# Altium schematic sheet main.SchDoc
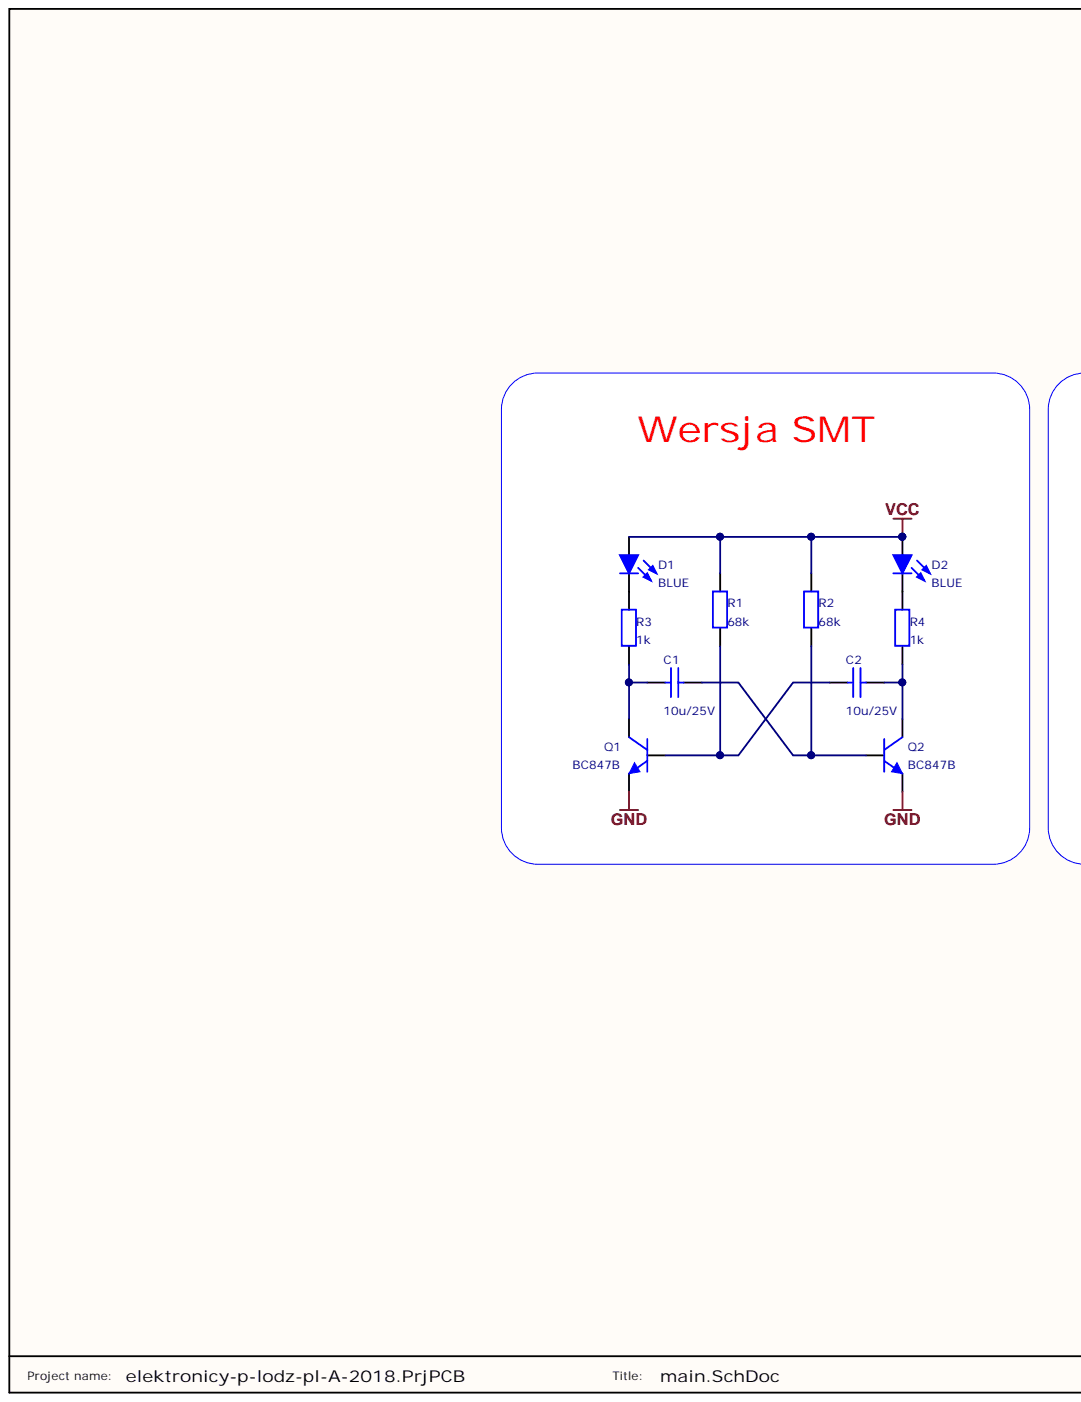
[diagram p0: main.SchDoc, left]
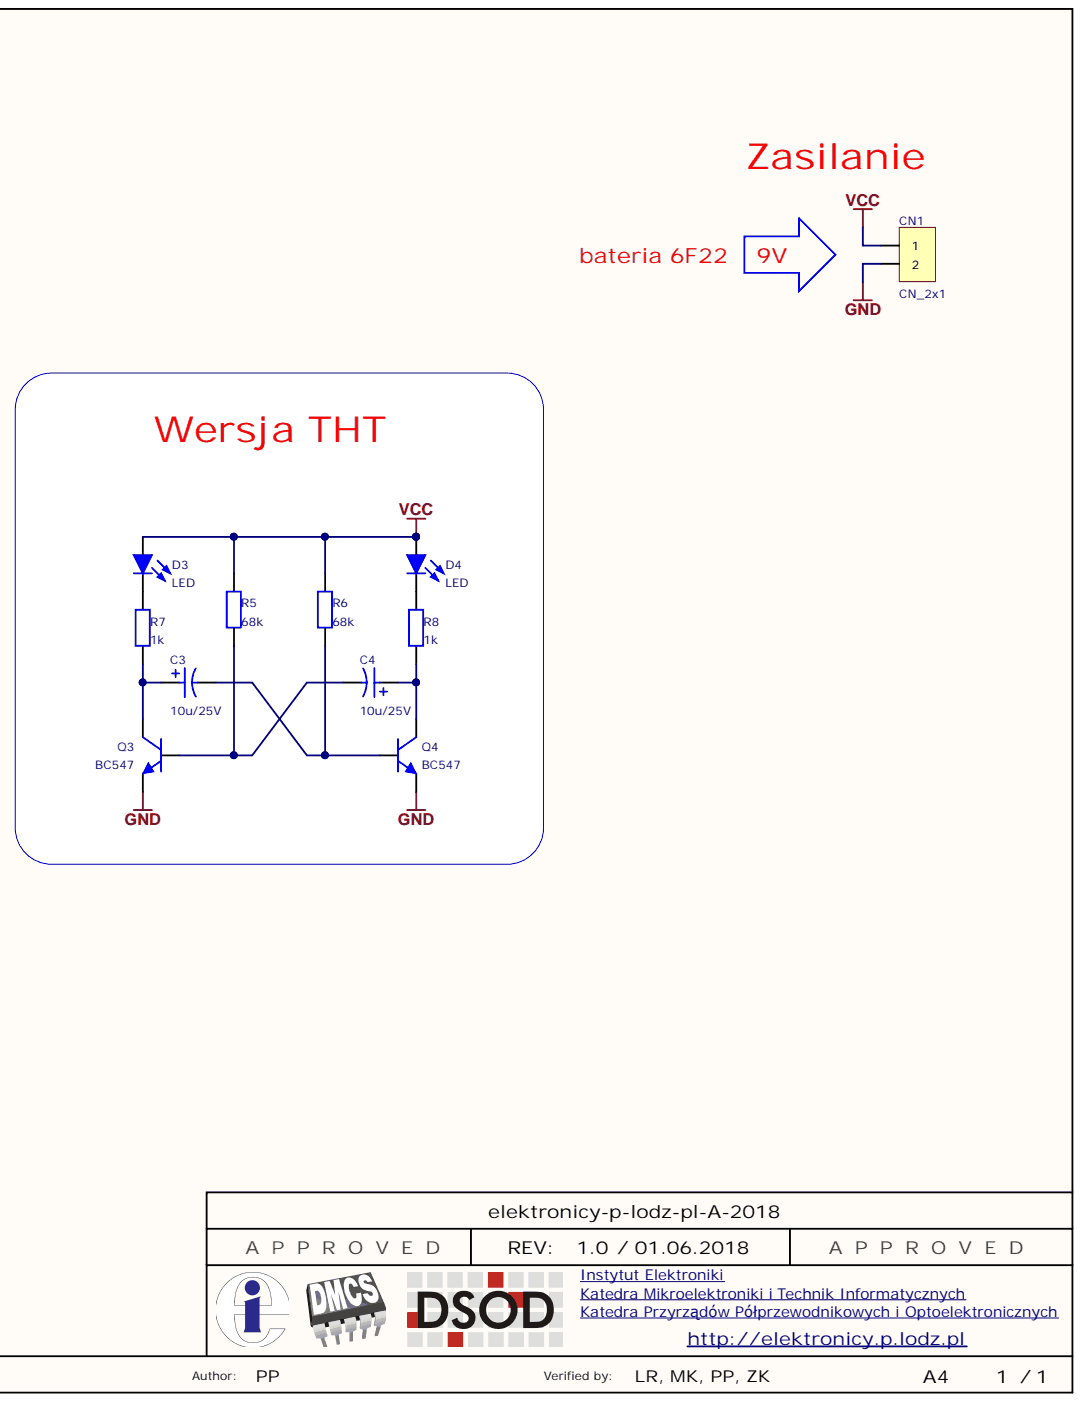
[diagram p1: main.SchDoc, right]

## Parts
C1  10u/25V  [C1206]
C2  10u/25V  [C1206]
C3  10u/25V  [CE5x11-2]
C4  10u/25V  [CE5x11-2]
CN1  CN_2x1  [GP_2x1]
D1  BLUE  [LED1206B]
D2  BLUE  [LED1206B]
D3  LED  [LED-Polarized]
D4  LED  [LED-Polarized]
Q1  BC847B  [SOT-23]
Q2  BC847B  [SOT-23]
Q3  BC547  [TO-92A]
Q4  BC547  [TO-92A]
R1  68k  [R1206]
R2  68k  [R1206]
R3  1k  [R1206]
R4  1k  [R1206]
R5  68k  [RES10.35-6.8X2.8]
R6  68k  [RES10.35-6.8X2.8]
R7  1k  [RES10.35-6.8X2.8]
R8  1k  [RES10.35-6.8X2.8]

## Nets
GND: CN1.2 Q1.2 Q2.2 Q3.3 Q4.3
VCC: CN1.1 D1.1 D2.1 D3.1 D4.1 R1.1 R2.1 R5.2 R6.2
NetC1_1: C1.1 Q1.3 R3.2
NetC1_2: C1.2 Q2.1 R2.2
NetC2_1: C2.1 Q1.1 R1.2
NetC2_2: C2.2 Q2.3 R4.2
NetC3_1: C3.1 Q3.1 R7.1
NetC3_2: C3.2 Q4.2 R6.1
NetC4_1: C4.1 Q4.1 R8.1
NetC4_2: C4.2 Q3.2 R5.1
NetD1_2: D1.2 R3.1
NetD2_2: D2.2 R4.1
NetD3_2: D3.2 R7.2
NetD4_2: D4.2 R8.2

## Library data of the parts
C1 C2 = Cap: Capacitor; Component Kind: Standard; ComponentLink1Description: datasheet; ComponentLink2Description: supplier; Library Name: capacitors.IntLib; Simulation: CAP; Value: 10u/25V
C3 C4 = Cap Pol: Polarized Capacitor; Component Kind: Standard; ComponentLink1Description: datasheet; ComponentLink2Description: supplier; Library Name: capacitors.IntLib; Simulation: CAP; Value: 10u/25V
D1 D2 = LED_BLUE: BLUE LED; Component Kind: Standard; Library Name: leds.IntLib; Published: 04-Apr-2006
D3 D4 = LED: Typical RED, GREEN, YELLOW, AMBER GaAs LED; Component Kind: Standard; Library Name: leds.IntLib; Published: 04-Apr-2006
Q1 Q2 = BC847B: NPN General Purpose Transistor (VCE=45V, IC=100mA, HFE=200-450); IC: 100mA; PowerRating: 250mW; VCEO: 45V; Component Kind: Standard; HFE: 200-450; Library Name: transistors_bjt.IntLib; Supplier 1: TME; Supplier 2: TME; Supplier 3: TME; Supplier 4: Farnell; Supplier 5: Farnell; Supplier 6: Farnell; Supplier 7: Farnell; Supplier 8: Farnell; Supplier 9: Farnell; Supplier Part Number 1: BC847B.215; Supplier Part Number 2: BC847B-DIO; Supplier Part Number 3: BC847B.235; Supplier Part Number 4: 1562449; Supplier Part Number 5: 1081232; Supplier Part Number 6: 1081232RL; Supplier Part Number 7: 1773608RL; Supplier Part Number 8: 2336781; Supplier Part Number 9: 1574343
Q3 Q4 = BC547: NPN General Purpose Transistor (VCE=45V, IC=100mA); Component Kind: Standard; Library Name: transistors_bjt.SchLib; Published: 21-Mar-2006
R1-R8 = Res: Resistor; Component Kind: Standard; ComponentLink1Description: datasheet; ComponentLink2Description: supplier; Library Name: resistors.IntLib; Published: 19-Feb-2006; Simulation: RES
  R1 R2 R5 R6: Value: 68k
  R3 R4 R7 R8: Value: 1k
CN1 = CN_2x1: Component Kind: Standard; Library Name: goldpin_male.IntLib
